# Altium schematic sheet P02_power.SchDoc
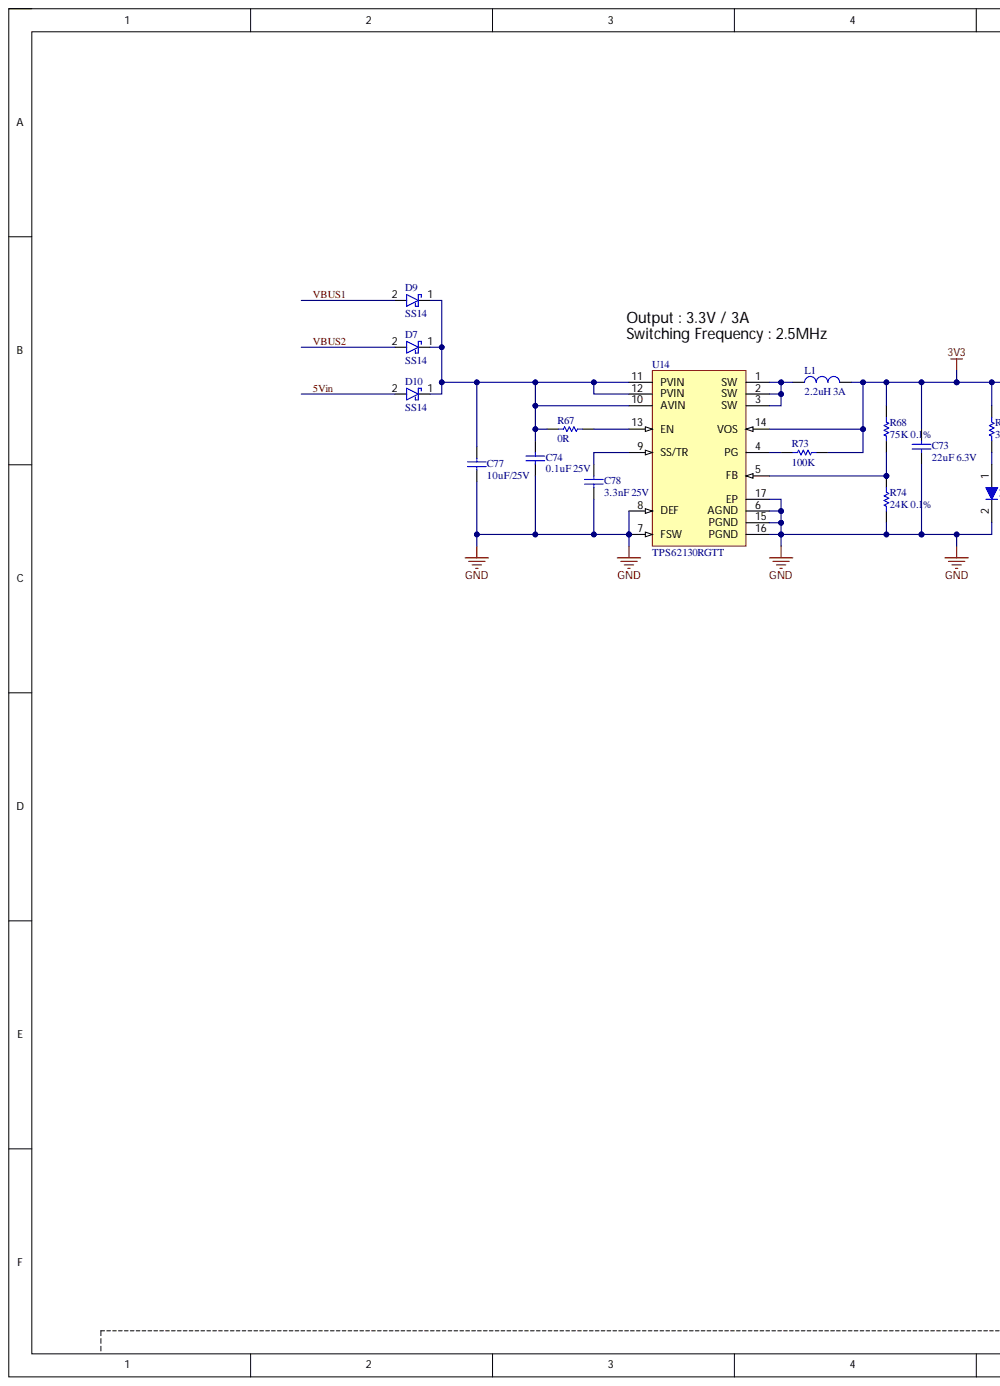
[diagram p0: P02_power.SchDoc, left]
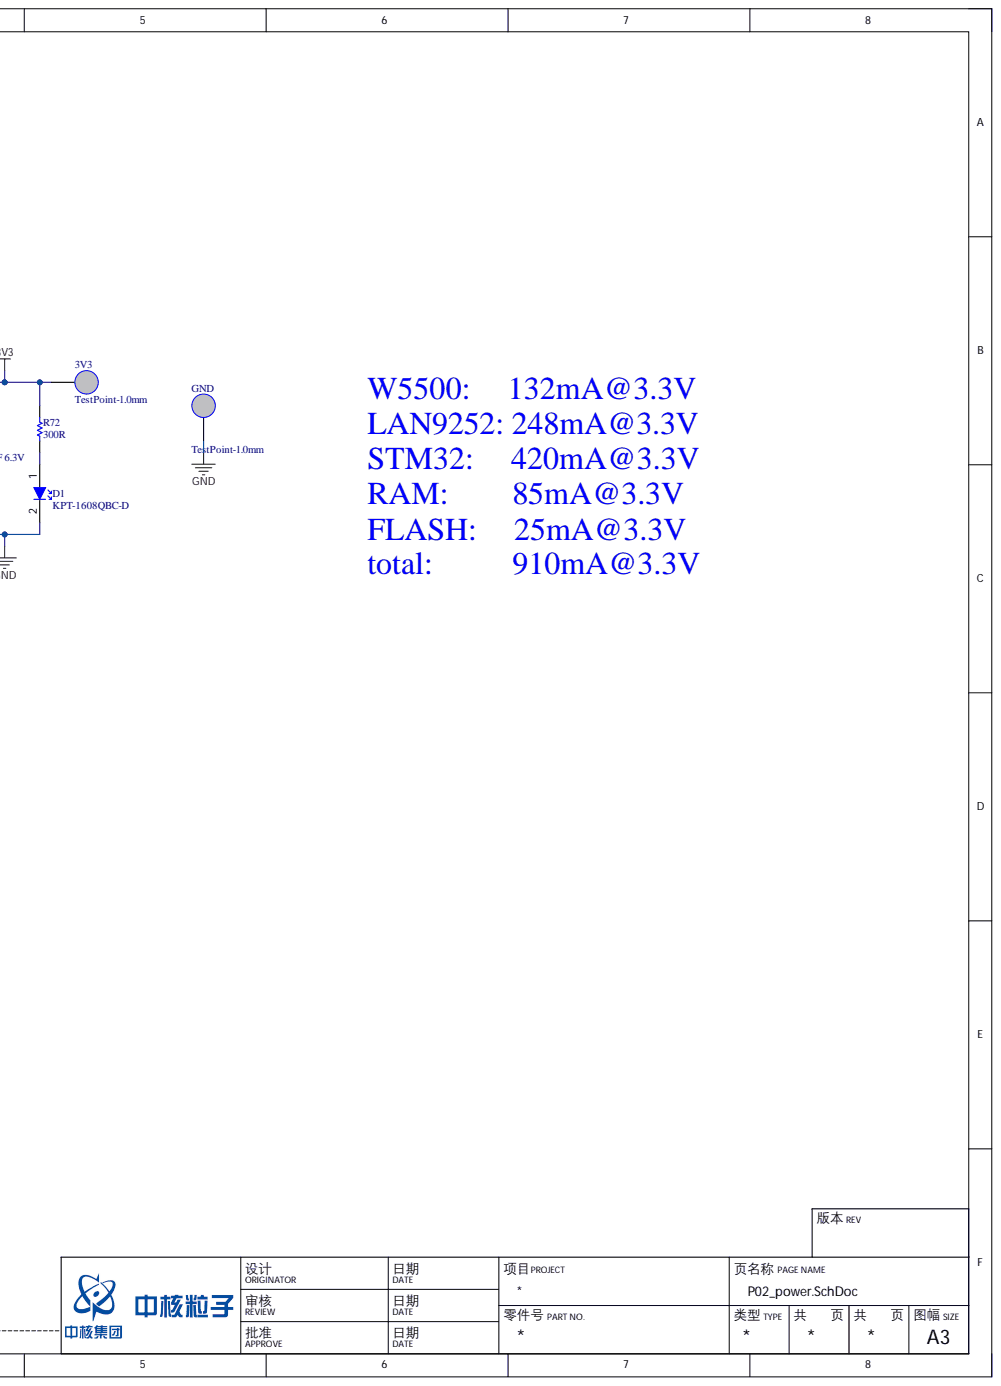
[diagram p1: P02_power.SchDoc, right]

## Parts
3V3  TestPoint-1.0mm  [TestPoint-1.0mm]
C73  22uF 6.3V  [C0805(2012)]
C74  0.1uF 25V  [C0402(1005)]
C77  10uF/25V  [C0805(2012)]
C78  3.3nF 25V  [C0402(1005)]
D1  KPT-1608QBC-D  [KPT-1608QBC-D]
D7  SS14  [DIONM5327X242N]
D9  SS14  [DIONM5327X242N]
D10  SS14  [DIONM5327X242N]
GND  TestPoint-1.0mm  [TestPoint-1.0mm]
L1  2.2uH 3A  [IHLP-1212BZ-11]
R67  0R  [R0402(1005)]
R68  75K 0.1%  [R0402(1005)]
R72  300R  [R0603(1608)]
R73  100K  [R0402(1005)]
R74  24K 0.1%  [R0402(1005)]
U14  TPS62130RGTT  [RGT0016C_V]

## Nets
3V3: 3V3.1 C73.2 L1.2 R68.2 R72.2 R73.2 U14.14(VOS)
GND: C73.1 C74.1 C77.1 C78.1 D1.2 GND.1 R74.1 U14.6(AGND) U14.7(FSW) U14.8(DEF) U14.15(PGND) U14.16(PGND) U14.17(EP)
5Vin: D10.2
VBUS1: D9.2
VBUS2: D7.2
NetC74_2: C74.2 C77.2 D7.1 D9.1 D10.1 R67.1 U14.10(AVIN) U14.11(PVIN) U14.12(PVIN)
NetC78_2: C78.2 U14.9(SS/TR)
NetD1_1: D1.1 R72.1
NetL1_1: L1.1 U14.1(SW) U14.2(SW) U14.3(SW)
NetR67_2: R67.2 U14.13(EN)
NetR68_1: R68.1 R74.2 U14.5(FB)
NetR73_1: R73.1 U14.4(PG)

## Library data of the parts
C73 = Cap 22uF 0805-6.3V: ceramic 6.3V; 价格: ￥1.759 @ 1; 制造商: KEMET; 型号: C0805C226M9PACTU; Component Kind: Standard; 供应商及库存编号: iCKey ET-1108326; Library Name: Core Ctrol Board V1.1.SCHLIB
C74 = Cap 0.1uF 0402-25V: ceramic 25V; 价格: ¥0.33@100; 制造商: KEMET; 型号: C0402C104M3RACTU; Component Kind: Standard; 供应商及库存编号: e络盟 2528342; Library Name: Core Ctrol Board V1.1.SCHLIB
C77 = Cap 10uF 0805-25V: ceramic 25V; 价格: ¥1.44@250; 制造商: KEMET; 型号: C0805C106K3PACTU; Component Kind: Standard; Library Name: RCL.SchLib; 供应商及库存编号: e络盟 2491176
C78 = Cap 3.3nF 0402-25V: ceramic 25V; 价格: ￥0.54@100; 制造商: KEMET; 型号: C0402C332J3RECAUTO7411; Component Kind: Standard; 供应商及库存编号: Mouser 80-C0402C332J3REAULR; Library Name: Core Ctrol Board V1.1.SCHLIB
D1 = LED KPT-1608QBC-D: LED, Blue， 0603; 价格: ￥1.13@10; 制造商: Kingbright; Component Kind: Standard; 供应商及库存编号: e络盟 2217978; 型号: KPT-1608QBC-D; Library Name: MLC Receiver.SCHLIB
D7 D9 D10 = SS14: Schottky Diode; Component Kind: Standard; Library Name: IC2022.SchLib
L1 = L 2.2uH 3A: 3.6mm x 3mm; 价格: ￥7.39@10; 制造商: VISHAY; 型号: IHLP1212BZER2R2M11; Component Kind: Standard; 供应商及库存编号: e络盟 2056527; Library Name: Core Ctrol Board V1.1.SCHLIB
R67 = Res 0R 0402: Res ±1%; Component Kind: Standard; Library Name: Core Ctrol Board V1.1.SCHLIB
R68 = Res 75K 0402: Res ±0.1%; Component Kind: Standard; Library Name: Core Ctrol Board V1.1.SCHLIB
R72 = Res 300R 0603: Res ±1%; Component Kind: Standard; Library Name: Core Ctrol Board V1.1.SCHLIB
R73 = Res 100K 0402: Res ±1%; Component Kind: Standard; Library Name: Core Ctrol Board V1.1.SCHLIB
R74 = Res 24K 0402 0.1%: Res ±0.1%; Component Kind: Standard; Library Name: Core Ctrol Board V1.1.SCHLIB
U14 = TPS62130RGTT: 直流-直流转换器, 降压稳压器, 2.5MHZ, QFN-16; 价格: ￥18.9@10; 制造商: TI; Component Kind: Standard; 供应商及库存编号: e络盟： 2455688; 型号: TPS62130RGTT; Library Name: Core Ctrol Board V1.1.SCHLIB
3V3 GND = TestPoint-1.0mm: Component Kind: Standard; Library Name: Connector2023.SchLib
